# Altium schematic sheet DC_DC_Converter_1.SchDoc
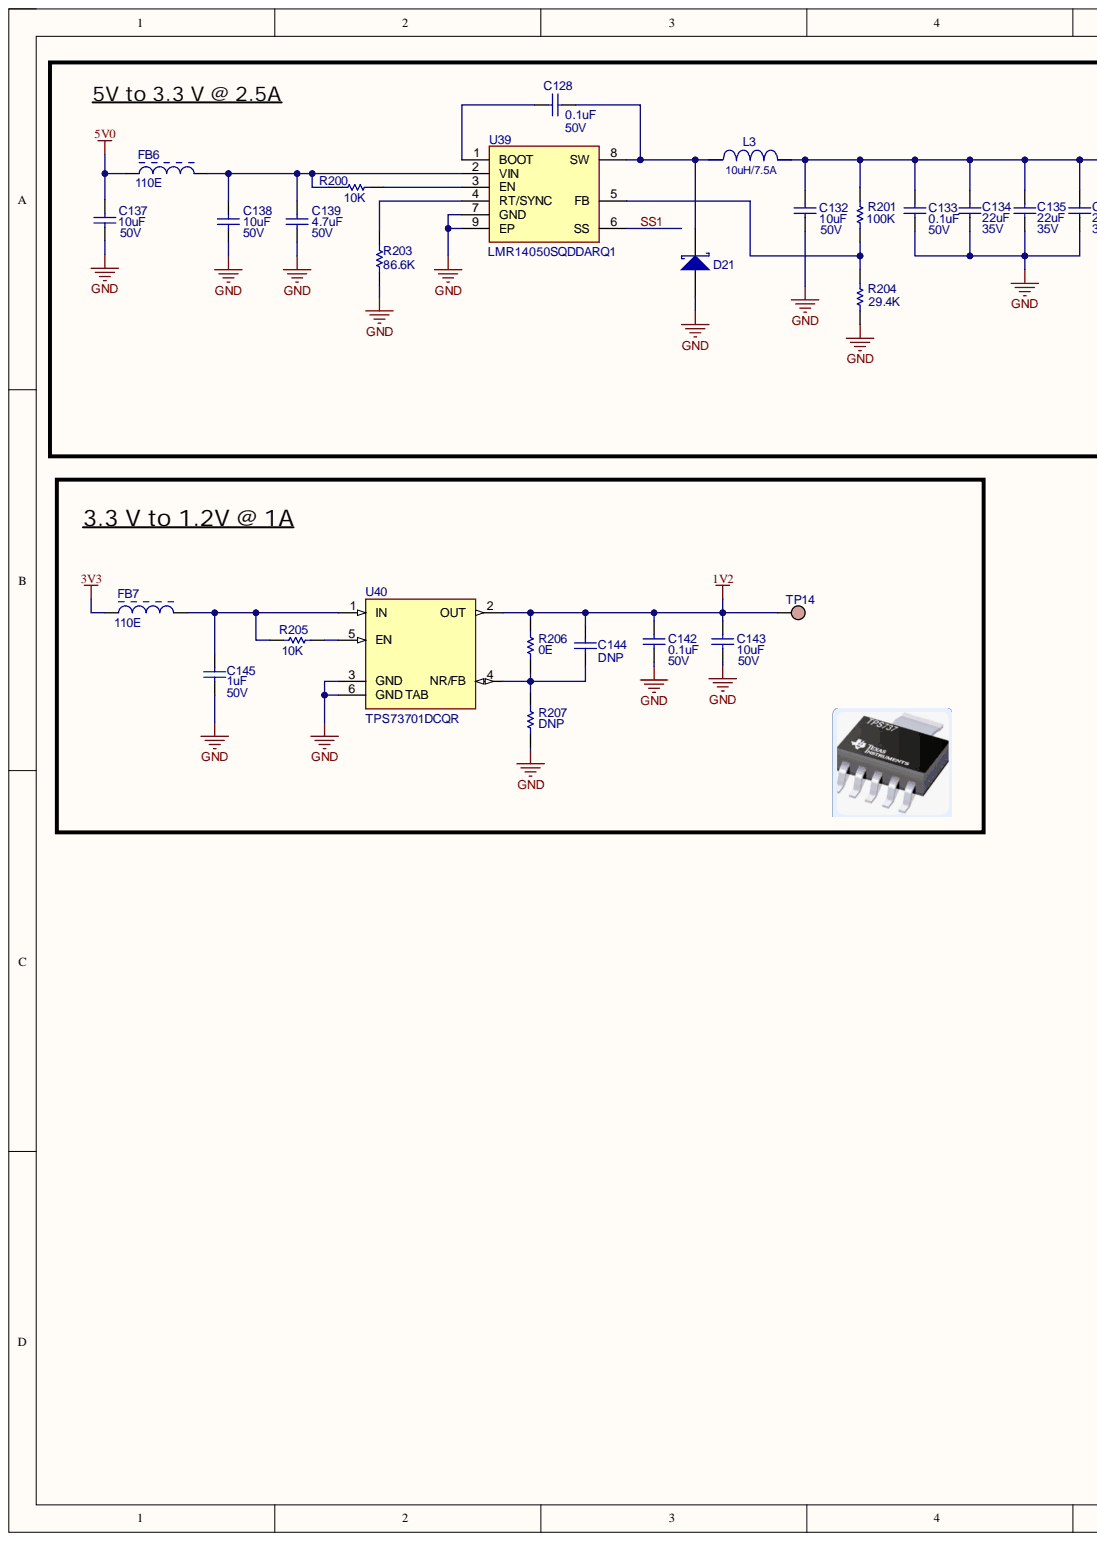
[diagram p0: DC_DC_Converter_1.SchDoc, left]
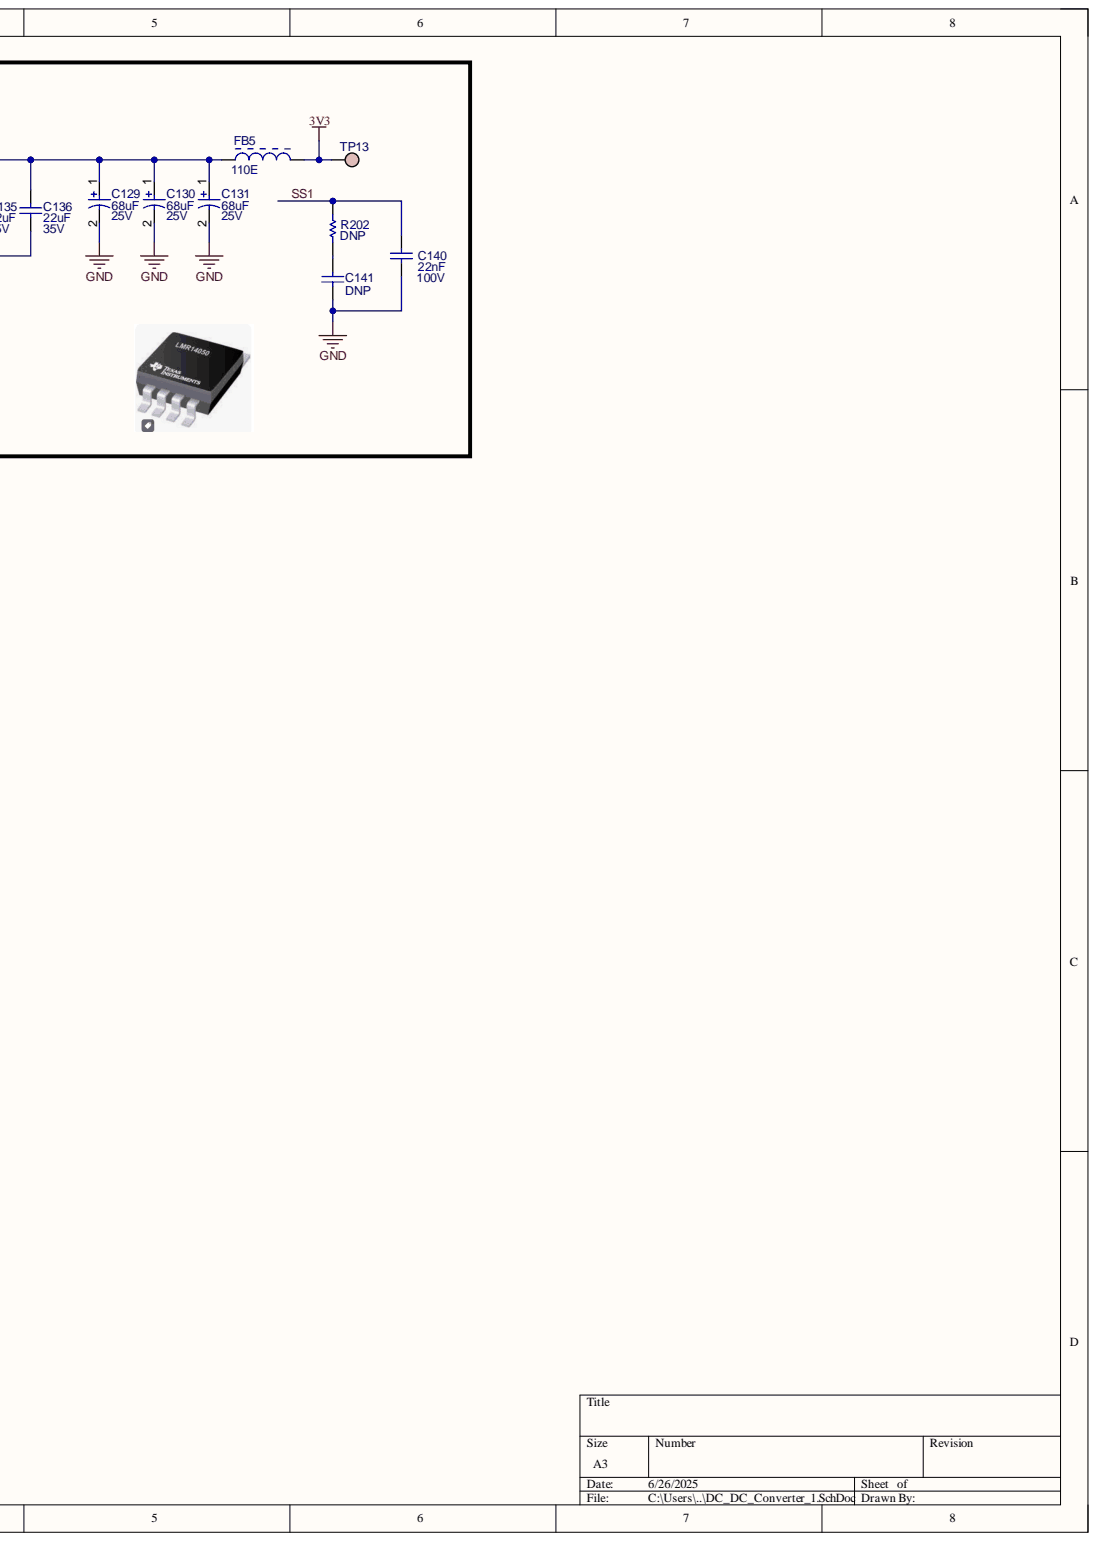
[diagram p1: DC_DC_Converter_1.SchDoc, right]

## Parts
C128  0.1uF  Voltage: 50V  [CAP-0805]
C129  68uF  Voltage Rating: 25V  [CAP_EEHZA1H220P]
C130  68uF  Voltage Rating: 25V  [CAP_EEHZA1H220P]
C131  68uF  Voltage Rating: 25V  [CAP_EEHZA1H220P]
C132  10uF  Voltage: 50V  [CAP-0805]
C133  0.1uF  Voltage: 50V  [CAP-0805]
C134  22uF  Voltage Rating: 35V  [CAP-0805]
C135  22uF  Voltage Rating: 35V  [CAP-0805]
C136  22uF  Voltage Rating: 35V  [CAP-0805]
C137  10uF  Voltage: 50V  [CAP-0805]
C138  10uF  Voltage: 50V  [CAP-0805]
C139  4.7uF  Voltage: 50V  [CAP-0805]
C140  22nF  Voltage: 100V  [CAP-0603]
C141  DNP  [CAP-0603]
C142  0.1uF  Voltage: 50V  [CAP-0805]
C143  10uF  Voltage: 50V  [CAP-0805]
C144  DNP  [CAP-0805]
C145  1uF  Voltage Rating: 50V  [CAP-0805]
D21  SK810L-TP  [DO-214AB (SMC)]  (value not drawn)
FB5  110E  [FB-0805]
FB6  110E  [FB-0805]
FB7  110E  [FB-0805]
L3  10uH/7.5A  [APCI00121210100T80]
R200  10K  [RES-0603]
R201  100K  [RES_0805]
R202  DNP  [RES-0603]
R203  86.6K  [RES_0805]
R204  29.4K  [RES_0805]
R205  10K  [RES-0603]
R206  0E  [RES_0805]
R207  DNP  [RES_0805]
TP13  TEST POINT  [TEST_POINT]  (value not drawn)
TP14  TEST POINT  [TEST_POINT]  (value not drawn)
U39  LMR14050SQDDARQ1  [IC-8-PowerSOIC-9N]
U40  TPS73701DCQR  [VREG_TPS73701DCQR]

## Nets
1V2: C142.2 C143.2 C144.2 R206.1 TP14.1 U40.2(OUT)
3V3: FB5.2 FB7.1 TP13.1
5V0: C137.2 FB6.1
GND: C129.2 C130.2 C131.2 C132.1 C133.1 C134.1 C135.1 C136.1 C137.1 C138.1 C139.1 C140.1 C141.1 C142.1 C143.1 C145.1 D21.1 R203.1 R204.1 R207.2 U39.7(GND) U39.9(EP) U40.3(GND) U40.6(GND_TAB)
SS1: C140.2 R202.2 U39.6(SS)
NetC128_1: C128.1 D21.2 L3.2 U39.8(SW)
NetC128_2: C128.2 U39.1(BOOT)
NetC129_1: C129.1 C130.1 C131.1 C132.2 C133.2 C134.2 C135.2 C136.2 FB5.1 L3.1 R201.2
NetC138_2: C138.2 C139.2 FB6.2 R200.1 U39.2(VIN)
NetC141_2: C141.2 R202.1
NetC144_1: C144.1 R206.2 R207.1 U40.4(NR/FB)
NetC145_2: C145.2 FB7.2 R205.1 U40.1(IN)
NetR200_2: R200.2 U39.3(EN)
NetR201_1: R201.1 R204.2 U39.5(FB)
NetR203_2: R203.2 U39.4(RT/SYNC)
NetR205_2: R205.2 U40.5(EN)

## Library data of the parts
C128 = CAP: CAP CER 0.1UF 50V X7R 0805; Manufacturer: KYOCERA AVX; Part Number: 08055C104J4Z2A; Tolerance: ±1%; Component Kind: Standard; Library Name: Daughter_board_SE3_V1.SCHLIB; Package: 0805; Technology: SMT; Value: 0.1uF
C129-C131 = CAP-P-2: CAP ALUM HYBRID 68UF 20% 25V SMD; Manufacturer: KEMET; Part Number: EEH-ZA1E680XP; ESR: 30mOhms; Component Kind: Standard; Library Name: Daughter_board_SE3_V1.SCHLIB; Package / Case: Radial; Tolerance: ±20%; Value: 68uF
C132 C137 C138 C143 = CAP: CAP CER 10UF 50V X5R 0805; Manufacturer: Murata Electronics; Part Number: GRM21BR61H106ME43L; Tolerance: ±1%; Component Kind: Standard; Library Name: Daughter_board_SE3_V1.SCHLIB; Package: 0805; Value: 10uF
C133 C142 = CAP: CAP CER 0.1UF 50V X7R 0805; Manufacturer: KYOCERA AVX; Part Number: 08055C104J4Z2A; Component Kind: Standard; Library Name: CAN_20-06-2023.SCHLIB; Package: 0603; Value: 0.1uF
C134-C136 = CAP_0805_N: CAP CER 22UF 35V X5R 0805; Manufacturer: TDK Corporation; Part Number: C2012X5R1V226M125AC; Tolerance: ±1%; Component Kind: Standard; Library Name: MB_Sch_Lib.SchLib; Operating Temperature: -55C ~ 85C; Package / Case: 0805 (2012 metric); Temperature Coefficient: X5R; Value: 22uF
C139 = CAP: CAP CER 4.7UF 50V X5R 0805; Manufacturer: Murata Electronics; Part Number: GRM21BR61H475KE51L; Tolerance: ±1%; Component Kind: Standard; Library Name: Daughter_board_SE3_V1.SCHLIB; Package: 0805; Value: 4.7uF
C140 = CAP: CAP CER 0.022UF 100V X7R 0603; Manufacturer: Murata Electronics; Part Number: GCM188R72A223KA37D; Case-Metric: 1608; Tolerance: ±10%; Component Kind: Standard; Library Name: Daughter_board_SE3_V1.SCHLIB; Package: 0603; Technology: SMT; Value: 22nF
C141 = CAP: NA; Case-Metric: 1608; Tolerance: ±5%; Component Kind: Standard; Library Name: Daughter_board_SE3_V1.SCHLIB; Manufacturer: NA; Package: 0603; Part Number: NA; Technology: SMT; Value: DNP; Voltage: NA
C144 = CAP: CAP CER 39PF 100V C0G/NP0 0805; Manufacturer: YAGEO; Part Number: CC0805FRNPO9BN390; Tolerance: ±1%; Voltage: 50V; Component Kind: Standard; Library Name: Daughter_board_SE3_V1.SCHLIB; Package: 0805; Technology: SMT; Value: 39pF
C145 = CAP_0805_N: CAP CER 1UF 50V X7R 0805; Manufacturer: Samsung Electro-Mechanics; Part Number: CL21B105KBFNNNG; Tolerance: ±1%; Component Kind: Standard; Library Name: MB_Sch_Lib.SchLib; Operating Temperature: -55C ~ 125C; Package / Case: 0805 (2012 metric); Temperature Coefficient: X7R; Value: 1uF
D21 = DSKY-AK-2: DIODE SCHOTTKY 100V 8A DO214AB; Manufacturer: MCC (Micro Commercial Components); Average Rectified Current-Max: 8A; Component Kind: Standard; Library Name: Daughter_board_SE3_V1.SCHLIB; Mounting Technology: SurfaceMount; Part Number: SK810L-TP; Reverse Voltage-Max [Vrrm]: 100V
FB5-FB7 = IND-FB-2: FERRITE BEAD 110 OHM 0805 1LN; Manufacturer: Murata Electronics; Part Number: BLM21SP111SZ1D; Current: 5A; Value: 110 Ohm; Component Kind: Standard; Library Name: Daughter_board_SE3_V1.SCHLIB; Package Reference: 0805
L3 = XAL6060-472MEB: FIXED IND 10UH 7.5A 18.3MOHM; Manufacturer: Pulse Electronics; Component Kind: Standard; Library Name: TI WEBENCH; Package: SMD/SMT; Part Number: APCI00121210100T80; Value: 10uH/7.5A
R200 R205 = RES-2: RES SMD 10K OHM 1% 1/10W 0603; Manufacturer: YAGEO; Part Number: AC0603FR-0710KL; Power Rating: 100mW; Component Kind: Standard; Library Name: Daughter_board_SE3_V1.SCHLIB; Package: 0603; Tolerance: ±1%; Value: 10K
R201 = RES-2: RES SMD 100K OHM 1% 1/8W 0805; Manufacturer: YAGEO; Part Number: AC0805FR-07100KL; Case/Package: 0805; Component Kind: Standard; Library Name: Daughter_board_SE3_V1.SCHLIB; Tolerance: ±1%; Value: 100K
R202 = RES-2: NA; Tolerance: ±1%; Case/Package: 0603; Component Kind: Standard; Library Name: Daughter_board_SE3_V1.SCHLIB; Manufacturer: NA; Part Number: NA; Value: DNP
R203 = RES-2: RES 86.6K OHM 1% 1/8W 0805; Manufacturer: YAGEO; Part Number: RC0805FR-0786K6L; Power Rating: 100mW; Case/Package: 0805; Component Kind: Standard; Library Name: Daughter_board_SE3_V1.SCHLIB; Tolerance: ±1%; Value: 86.6K
R204 = RES-2: RES 29.4K OHM 1% 1/8W 0805; Manufacturer: YAGEO; Part Number: RC0805FR-0729K4L; Case/Package: 0805; Component Kind: Standard; Library Name: Daughter_board_SE3_V1.SCHLIB; Tolerance: ±1%; Value: 29.4K
R206 = RES-2: RES 0 OHM JUMPER 1/10W 0805; Manufacturer: YAGEO; Part Number: AC0805JR-070RL; Power: 0.1W; Tolerance: ±1%; Component Kind: Standard; Library Name: Daughter_board_SE3_V1.SCHLIB; Package: 0805; Value: 0E
R207 = RES-2: RES 0 OHM JUMPER 1/10W 0805; Manufacturer: YAGEO; Part Number: AC0805JR-070RL; Power: 0.1W; Tolerance: ±1%; Value: 0E; Component Kind: Standard; Library Name: Daughter_board_SE3_V1.SCHLIB; Package: 0805
TP13 TP14 = TP: Test Point 0.66mm DIA; Component Kind: Standard; Part Number: Test Point; Library Name: GENSET CONTROLLER BETA V1.SCHLIB
U39 = TPS54531DDAR: IC REG BUCK ADJ 5A 8SOPWR; Manufacturer: Diodes Incorporated; Value: 4-40V; Component Kind: Standard; Package: SO-PowerPad-8; Part Number: LMR14050SQDDARQ1; Library Name: MOTHERBOARD_PI_COMPUTE_MODULE_4_1.SCHLIB
U40 = TPS73701DCQR: IC REG LINEAR POS ADJ 1A SOT223; Manufacturer: Texas Instruments; Component Kind: Standard; Part Number: TPS73701DCQR; Price: None
